# Altium schematic sheet FRAM.SchDoc
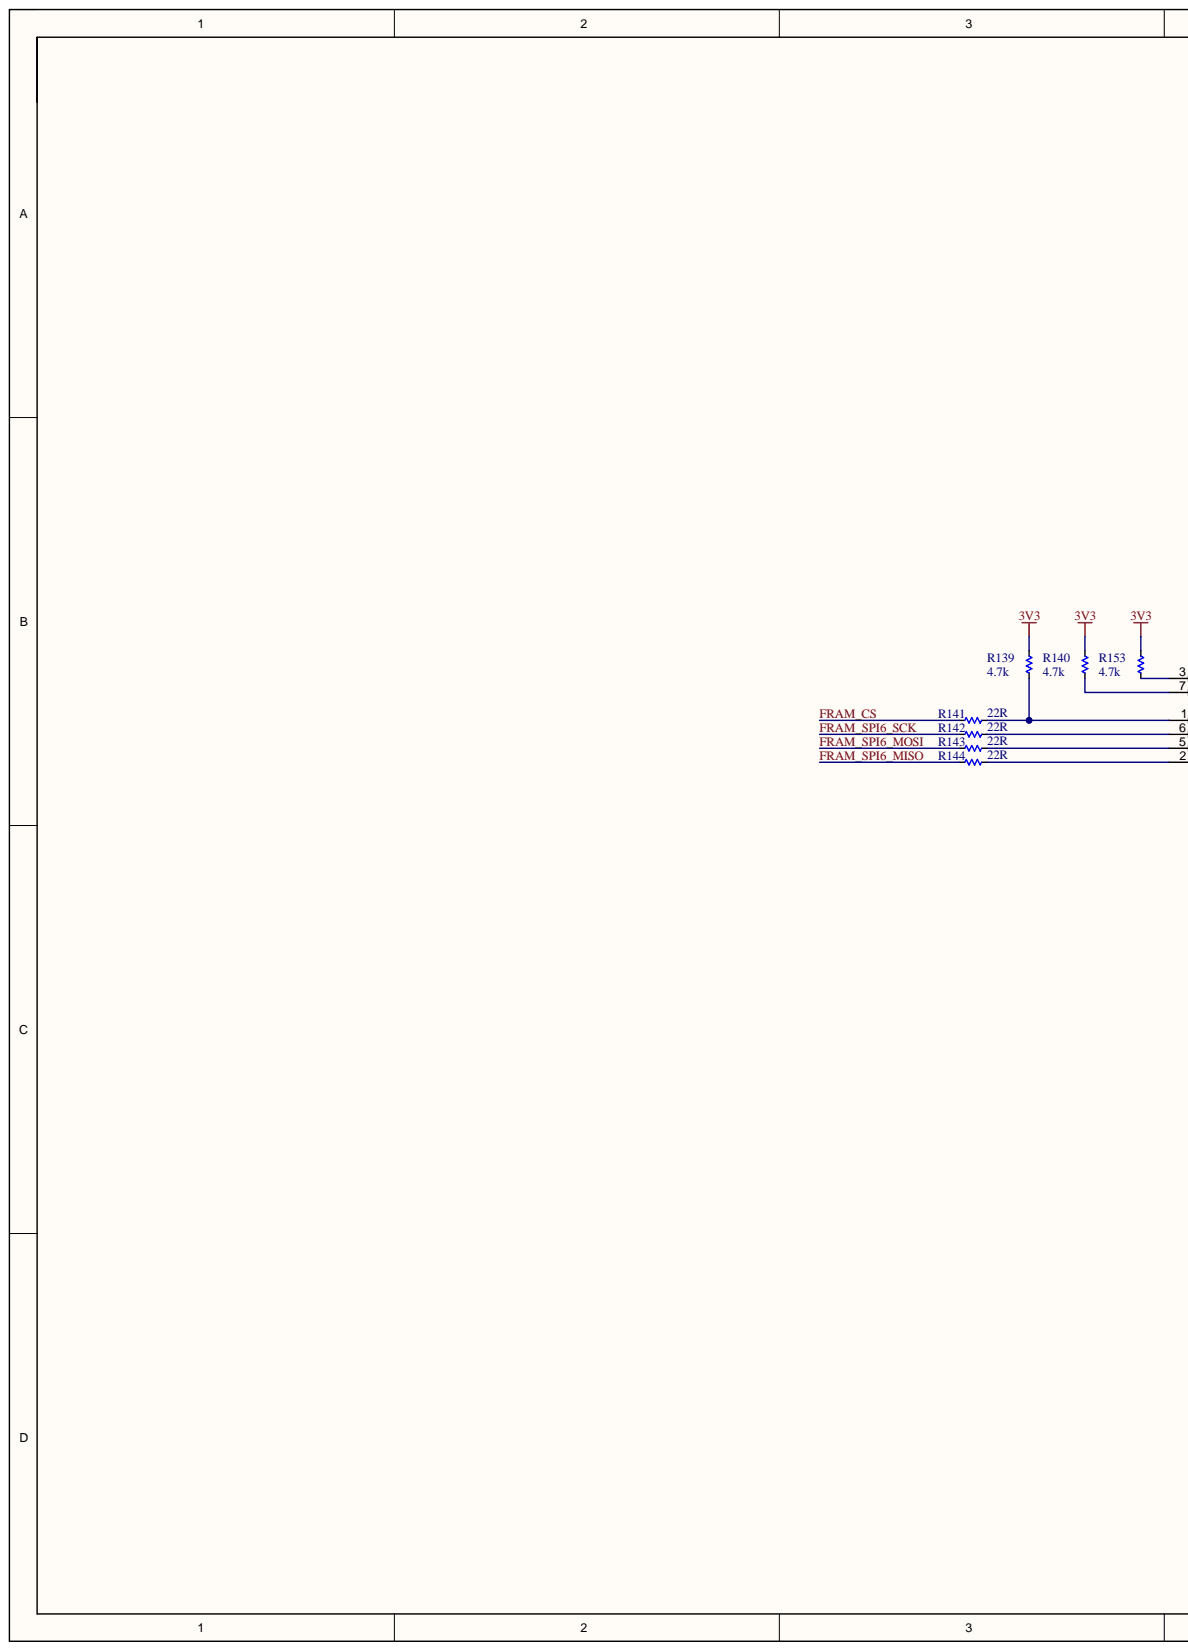
[diagram p0: FRAM.SchDoc, left]
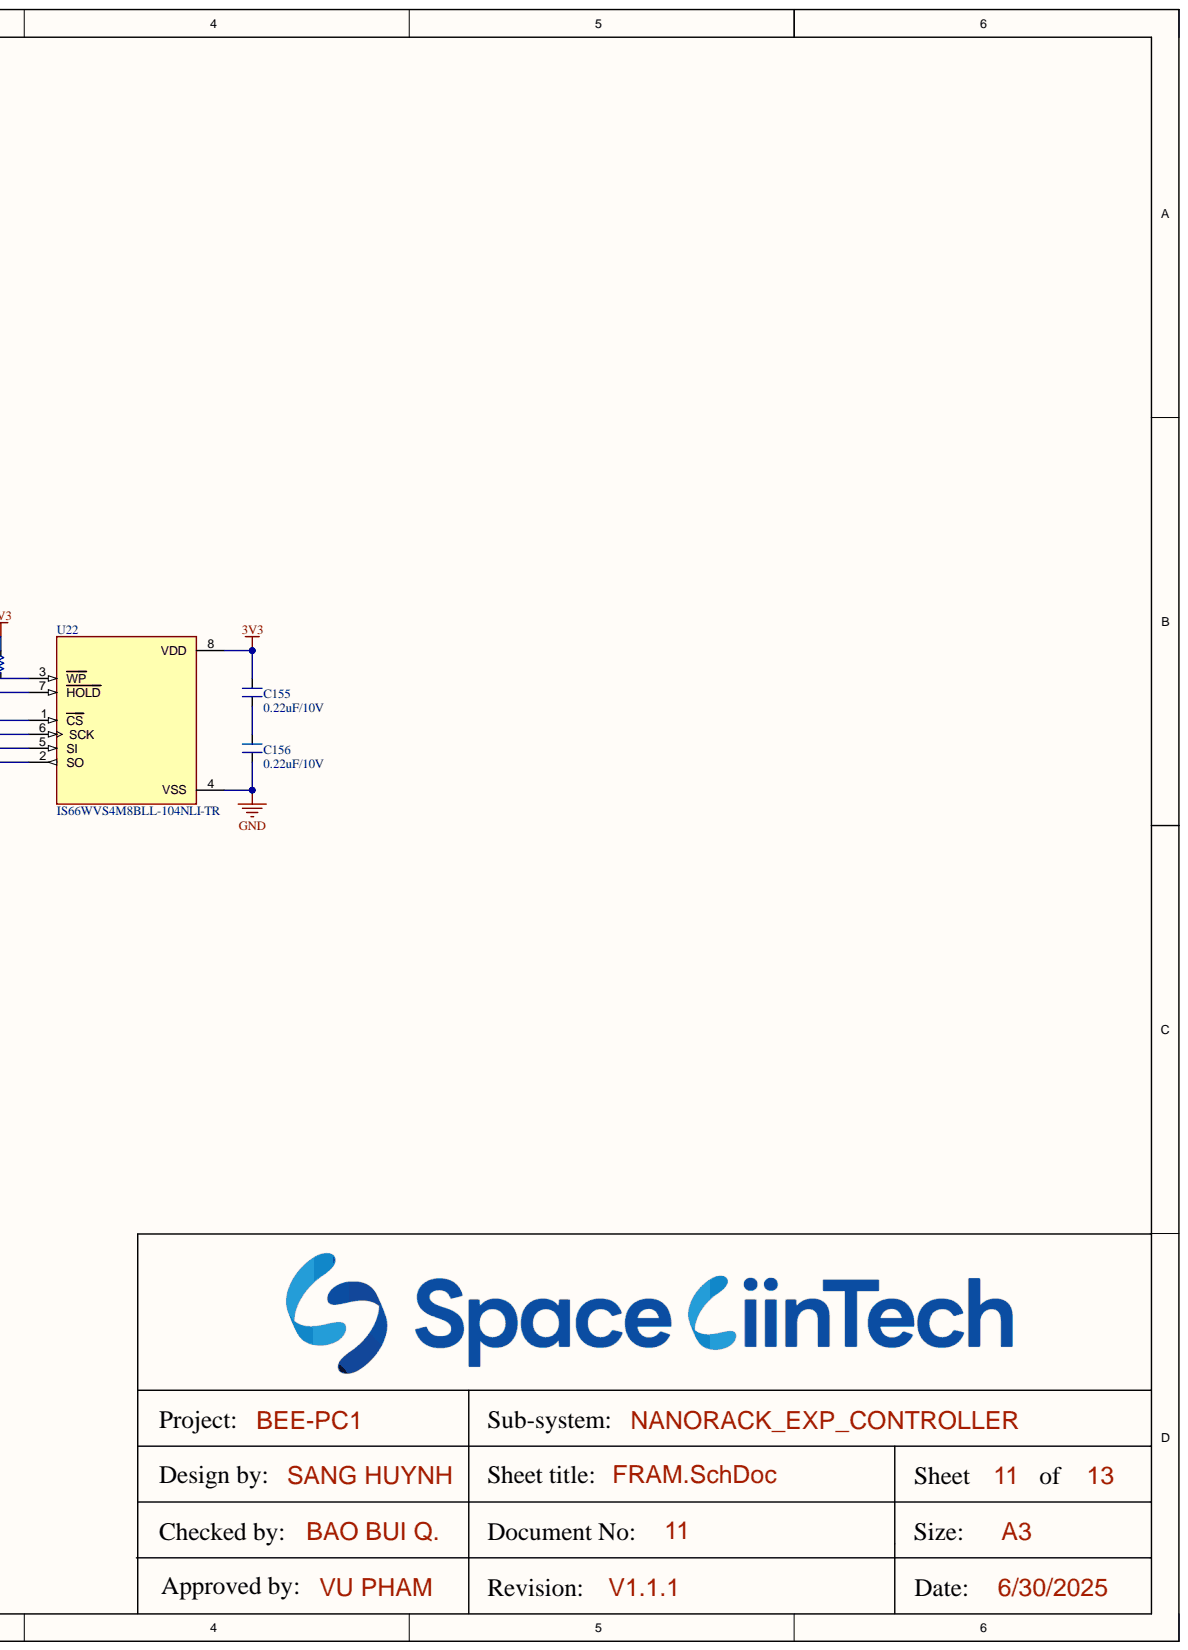
[diagram p1: FRAM.SchDoc, right]

## Parts
C155  0.22uF/10V  [CAP_C_1608X80]
C156  0.22uF/10V  [CAP_C_1608X80]
R139  4.7k  [RES_C_1608X60]
R140  4.7k  [RES_C_1608X60]
R141  22R  [RES_C_1608X60]
R142  22R  [RES_C_1608X60]
R143  22R  [RES_C_1608X60]
R144  22R  [RES_C_1608X60]
R153  4.7k  [RES_C_1608X60]
U22  IS66WVS4M8BLL-104NLI-TR  [IS66WVS4M8BLL-104NLI-TR]

## Nets
3V3: C155.2 R139.1 R140.1 R153.1 U22.8(VDD)
GND: C156.1 U22.4(VSS)
FRAM_CS: R141.1
FRAM_SPI6_MISO: R144.1
FRAM_SPI6_MOSI: R143.1
FRAM_SPI6_SCK: R142.1
NetC155_1: C155.1 C156.2
NetR139_2: R139.2 R141.2 U22.1(CS)
NetR140_2: R140.2 U22.7(HOLD)
NetR142_2: R142.2 U22.6(SCK)
NetR143_2: R143.2 U22.5(SI)
NetR144_2: R144.2 U22.2(SO)
NetR153_2: R153.2 U22.3(WP)

## Library data of the parts
C155 C156 = Capacitors: Value: 33pF; Component Kind: Standard; Library Name: EXP_HW_V3.SchLib
R139-R144 R153 = Res SMD: SMD; Manufacturer: Generic Components; Class: Passive; Component Kind: Standard; Published: 08/06/2000; Library Name: EXP_HW_V3.SchLib; Publisher: Altium Hobart Technology Centre
U22 = IS66WVS4M8BLL-104NLI-TR: IC PSRAM 32MBIT SPI QPI 8SOIC; MF: Fujitsu Electronics; MP: MB85RS2MTAPNF-G-BDERE1; Component Kind: Standard; Digi-Key_Part_Number: 865-1286-1-ND; Digi-Key_Purchase_URL: https://www.digikey.com/product-detail/en/fujitsu-electronics-america-inc/MB85RS2MTAPNF-G-BDERE1/865-1286-1-ND/7916252?utm_source=snapeda&utm_medium=aggregator&utm_campaign=symbol; Package: SO-8 Fujitsu; SnapEDA_Link: https://www.snapeda.com/parts/MB85RS2MTAPNF-G-BDERE1/Fujitsu%20Electronics%20America%2C%20Inc./view-part/2344795/?ref=snap; Library Name: EXP_HW_V3.SchLib
